# Altium schematic sheet PowerOutCurrentMonitor.SchDoc
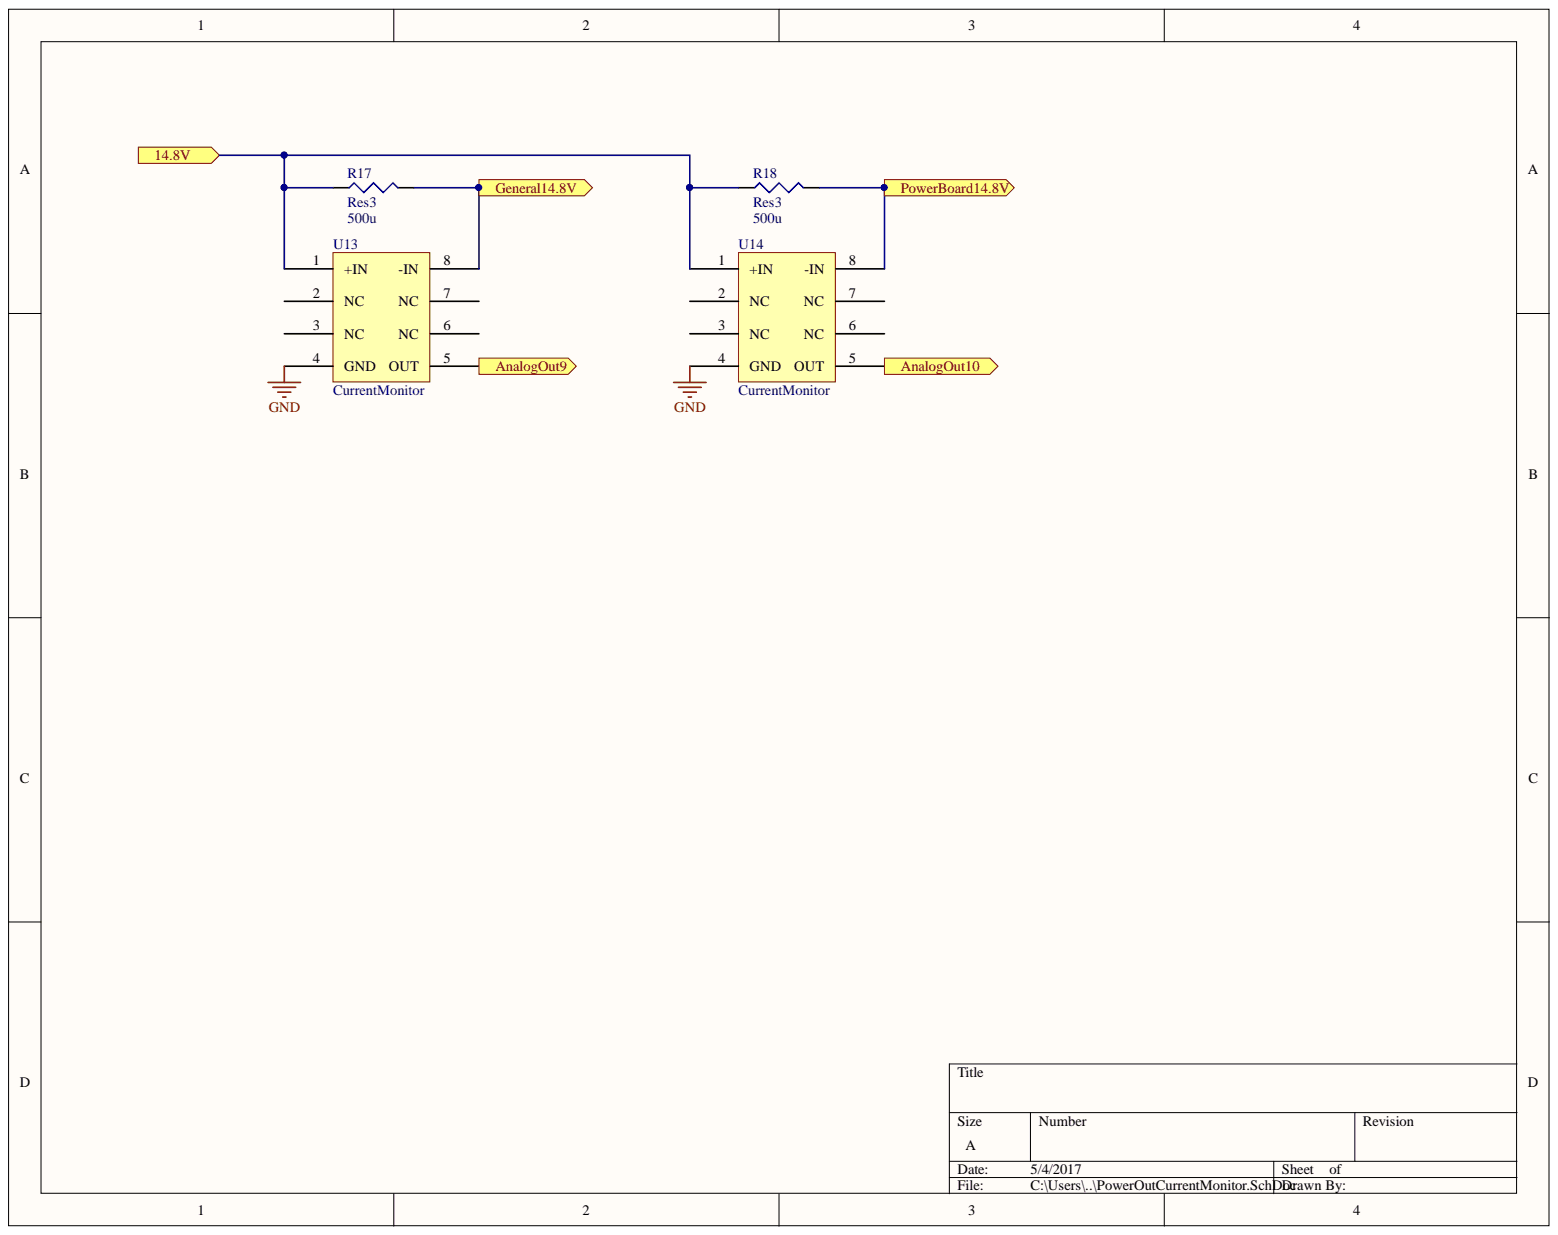
[diagram p0: PowerOutCurrentMonitor.SchDoc]

## Parts
R17  Res3  value 500u  [Resistor05MOHM]
R18  Res3  value 500u  [Resistor05MOHM]
U13  CurrentMonitor  [AD8217]
U14  CurrentMonitor  [AD8217]

## Ports
14.8V, ANALOGOUT9, ANALOGOUT10, GENERAL14.8V, POWERBOARD14.8V

## Nets
GND: U13.4(GND) U14.4(GND)
14.8V: R17.1 R18.1 U13.1(+IN) U14.1(+IN) ; port 14.8V
NetR17_2: R17.2 U13.8(-IN) ; port GENERAL14.8V
NetR18_2: R18.2 U14.8(-IN) ; port POWERBOARD14.8V
NetU13_5: U13.5(OUT) ; port ANALOGOUT9
NetU14_5: U14.5(OUT) ; port ANALOGOUT10

Not connected: U13 2(NC) 3(NC) 6(NC) 7(NC); U14 2(NC) 3(NC) 6(NC) 7(NC)

## Library data of the parts
R17 R18 = Res3: Resistor; Value: 500u; Component Kind: Standard; LatestRevisionDate: 29-May-2009; LatestRevisionNote: IPC-7351 Footprint Added.; Library Name: Miscellaneous Devices.IntLib; PackageDescription: Chip Resistor; PackageReference: J1-0603; Published: 8-Jun-2000; Signal Integrity: Res; Simulation: RESISTOR; Publisher: Altium Limited
U13 U14 = AD8217: Component Kind: Standard; Library Name: AD8217.SchLib
